# Altium schematic sheet Power_Supply.SchDoc(Power_Supply)
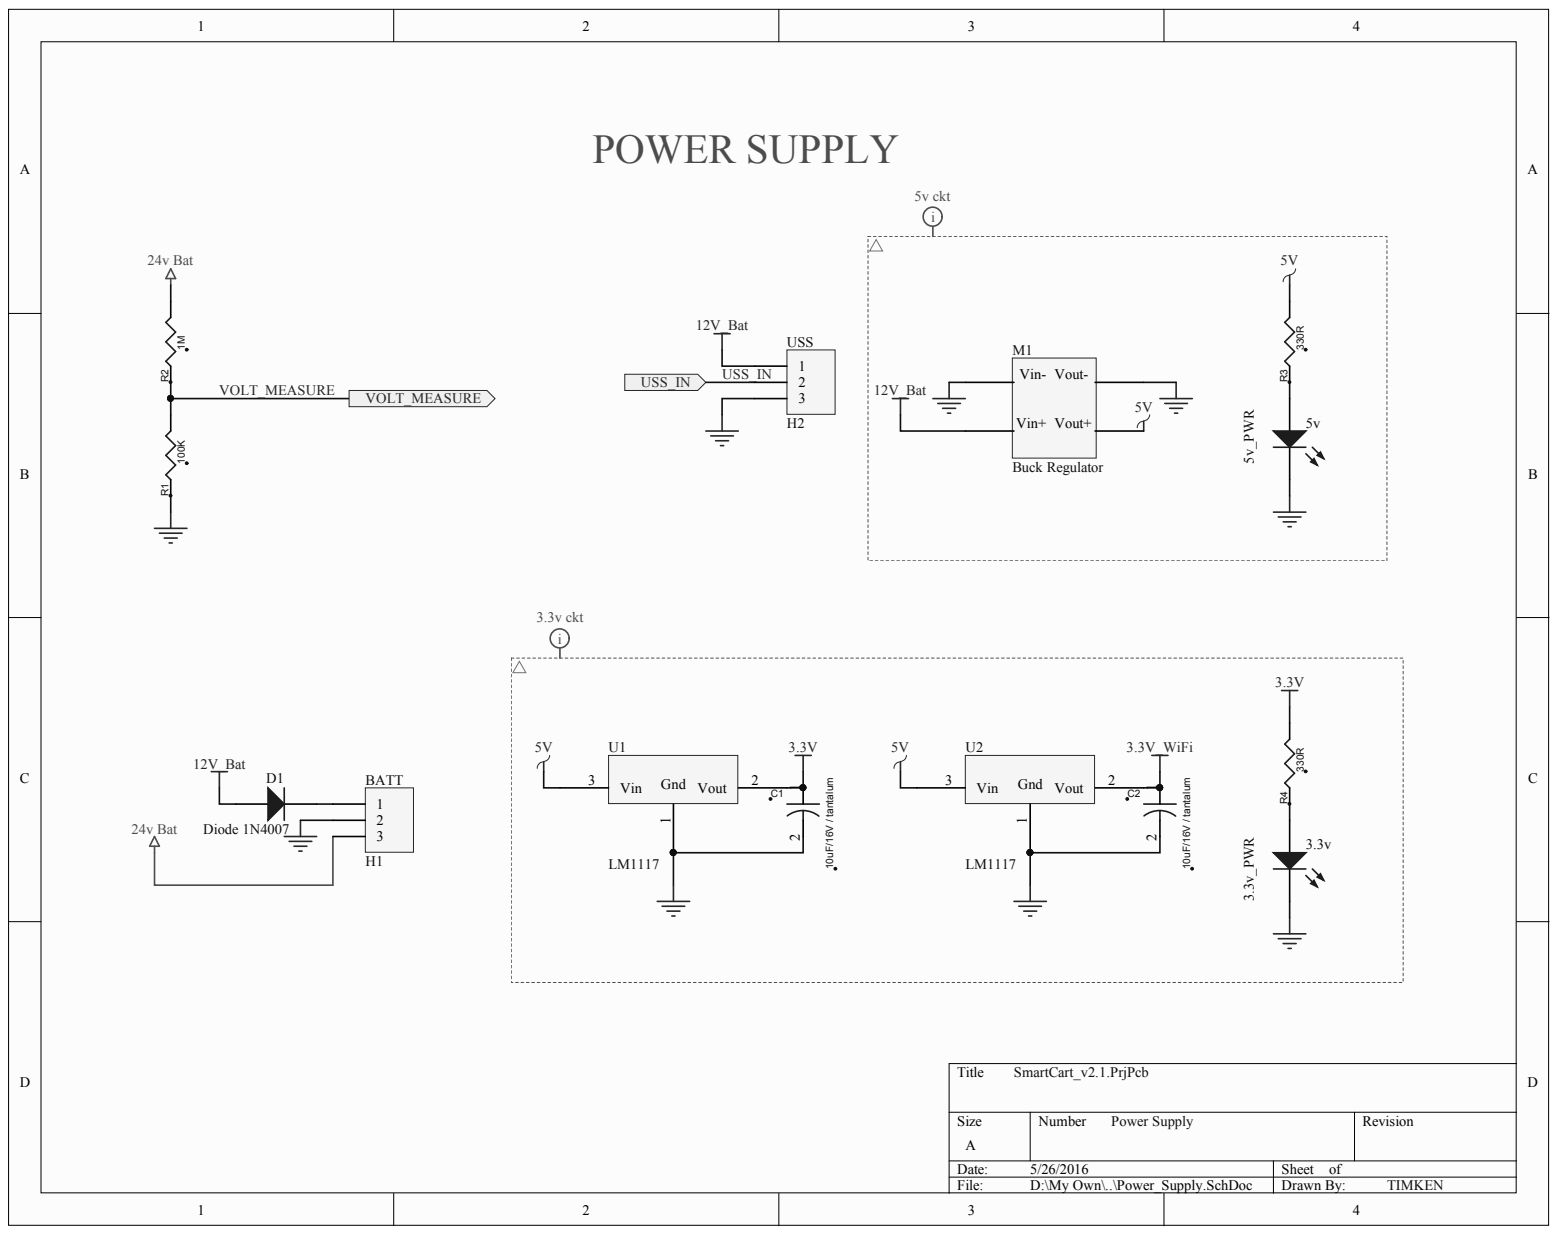
[diagram p0: Power_Supply.SchDoc]

## Parts
3.3v  3.3v_PWR  [LED_SMD]
5v  5v_PWR  [LED_SMD]
BATT  H1  [BATTERY_3PIN]
C1  10uF/16V / tantalum  [C1210]
C2  10uF/16V / tantalum  [C1210]
D1  Diode 1N4007  [D-IN4007]
M1  Buck Regulator  [BUCKREGULATOR]
R1  100K  [0.079" L x 0.049" W (2.00mm x 1.25mm)  (0805 (2012 Metric)  )]
R2  1M  [0.079" L x 0.049" W (2.00mm x 1.25mm)  (0805 (2012 Metric)  )]
R3  330R  [0.079" L x 0.049" W (2.00mm x 1.25mm)  (0805 (2012 Metric)  )]
R4  330R  [0.079" L x 0.049" W (2.00mm x 1.25mm)  (0805 (2012 Metric)  )]
U1  LM1117  [LM1117]
U2  LM1117  [LM1117]
USS  H2  [1x3_single - duplicate]

## Ports
USS_IN, VOLT_MEASURE

## Nets
3.3V: C1.1 R4.2 U1.2
3.3V_WIFI: C2.1 U2.2
5V: M1.Vout+ R3.2 U1.3 U2.3
12V_BAT: D1.1 M1.Vin+ USS.1
24V BAT: BATT.3 R2.2
GND_POWER: 3.3v.2 5v.2 BATT.2 C1.2 C2.2 M1.Vin- M1.Vout- R1.1 U1.1 U2.1 USS.3
USS_IN: USS.2 ; port USS_IN
VOLT_MEASURE: R1.2 R2.1 ; port VOLT_MEASURE
Net3.3v_1: 3.3v.1 R4.1
Net5v_1: 5v.1 R3.1
NetBATT_1: BATT.1 D1.2
N00173:
N00174:

## Library data of the parts
3.3v 5v = LED_SMD: Typical INFRARED GaAs LED_throughole; Component Kind: Standard; LatestRevisionDate: 08-Jul-2005; LatestRevisionNote: Mech Layer 1 removed.; PackageDescription: LED; 2 Leads; PackageReference: LED-0; Published: 8-Jun-2000; Library Name: combined_cart_schematic_lib.SchLib; Publisher: Altium Limited
BATT = BATTERY_3PIN: Header, 3-Pin; : 1.27mm pITCH; Component Kind: Standard; Library Name: combined_cart_schematic_lib.SchLib
C1 C2 = C_0: Descr: CAP TANT 10UF 16V 10% 1210,0.138" L x 0.110" W (3.50mm x 2.80mm); M P No: TPSB106K016R0500; Vendor: Digikey; V P No: 478-5230-6-ND; Component Kind: Standard; Library Name: combined_cart_schematic_lib.SchLib
D1 = Diode 1N4007: 1 Amp General Purpose Rectifier; Code_JEDEC: DO-204-AL; Component Kind: Standard; ComponentLink1Description: Manufacturer Link; ComponentLink1URL: http://www.fairchildsemi.com/; ComponentLink2Description: Datasheet; ComponentLink2URL: http://www.fairchildsemi.com/ds/1N%2F1N4001.pdf; DatasheetVersion: 1998; LatestRevisionDate: 17-Jul-2002; LatestRevisionNote: Re-released for DXP Platform.; PackageDescription: Diode, Axial; Body 5.2 x 2.7 mm (LxDia. max), Lead Dia. 0.86 mm (max); PackageReference: DO-41; PackageVersion: Aug-1999; Published: 8-Jun-2000; Library Name: combined_cart_schematic_lib.SchLib; Publisher: Altium Limited
M1 = Regulator: buck regulator\; ArchiveName: GENERIC; ClockTrigger: Rising; Enable: High; FunctionalClass: Counter; Loadable: True; PortSize: 4; PortType: Single Pin Version; Reset: Asynchronous; SubClass: Binary; VHDLIB_SIM: GENERIC_LIB; XilinxEquivalent: CB4CLE; Component Kind: Standard; ComponentLink1Description: Core &Resource Usage; ComponentLink1URL: CR0130 FPGA Counter Resource Usage.pdf#page=18; HelpURL: CR0118 FPGA Generic Library Guide.pdf#page=130; LastRevisionNo: 1.00.00; Published: 29-Jan-2004; Library Name: combined_cart_schematic_lib.SchLib; Publisher: Altium Limited
R1-R4 = RESISTOR: Descr: RES 10K OHM 1/2W 5% 0805 SMD; M P No: ERJ-P06J103V; Vendor: Digikey; V P No: P10KADTR-ND; Component Kind: Standard; Library Name: combined_cart_schematic_lib.SchLib
USS = Header 3: Header, 3-Pin; Component Kind: Standard; LatestRevisionDate: 17-Jul-2002; LatestRevisionNote: Re-released for DXP Platform.; Library Name: combined_cart_schematic_lib.SchLib; Publisher: Altium Limited
U1 U2 = LM1117: Component Kind: Standard; Library Name: combined_cart_schematic_lib.SchLib
